# Altium schematic sheet Power_ext.SchDoc(Power_ext)
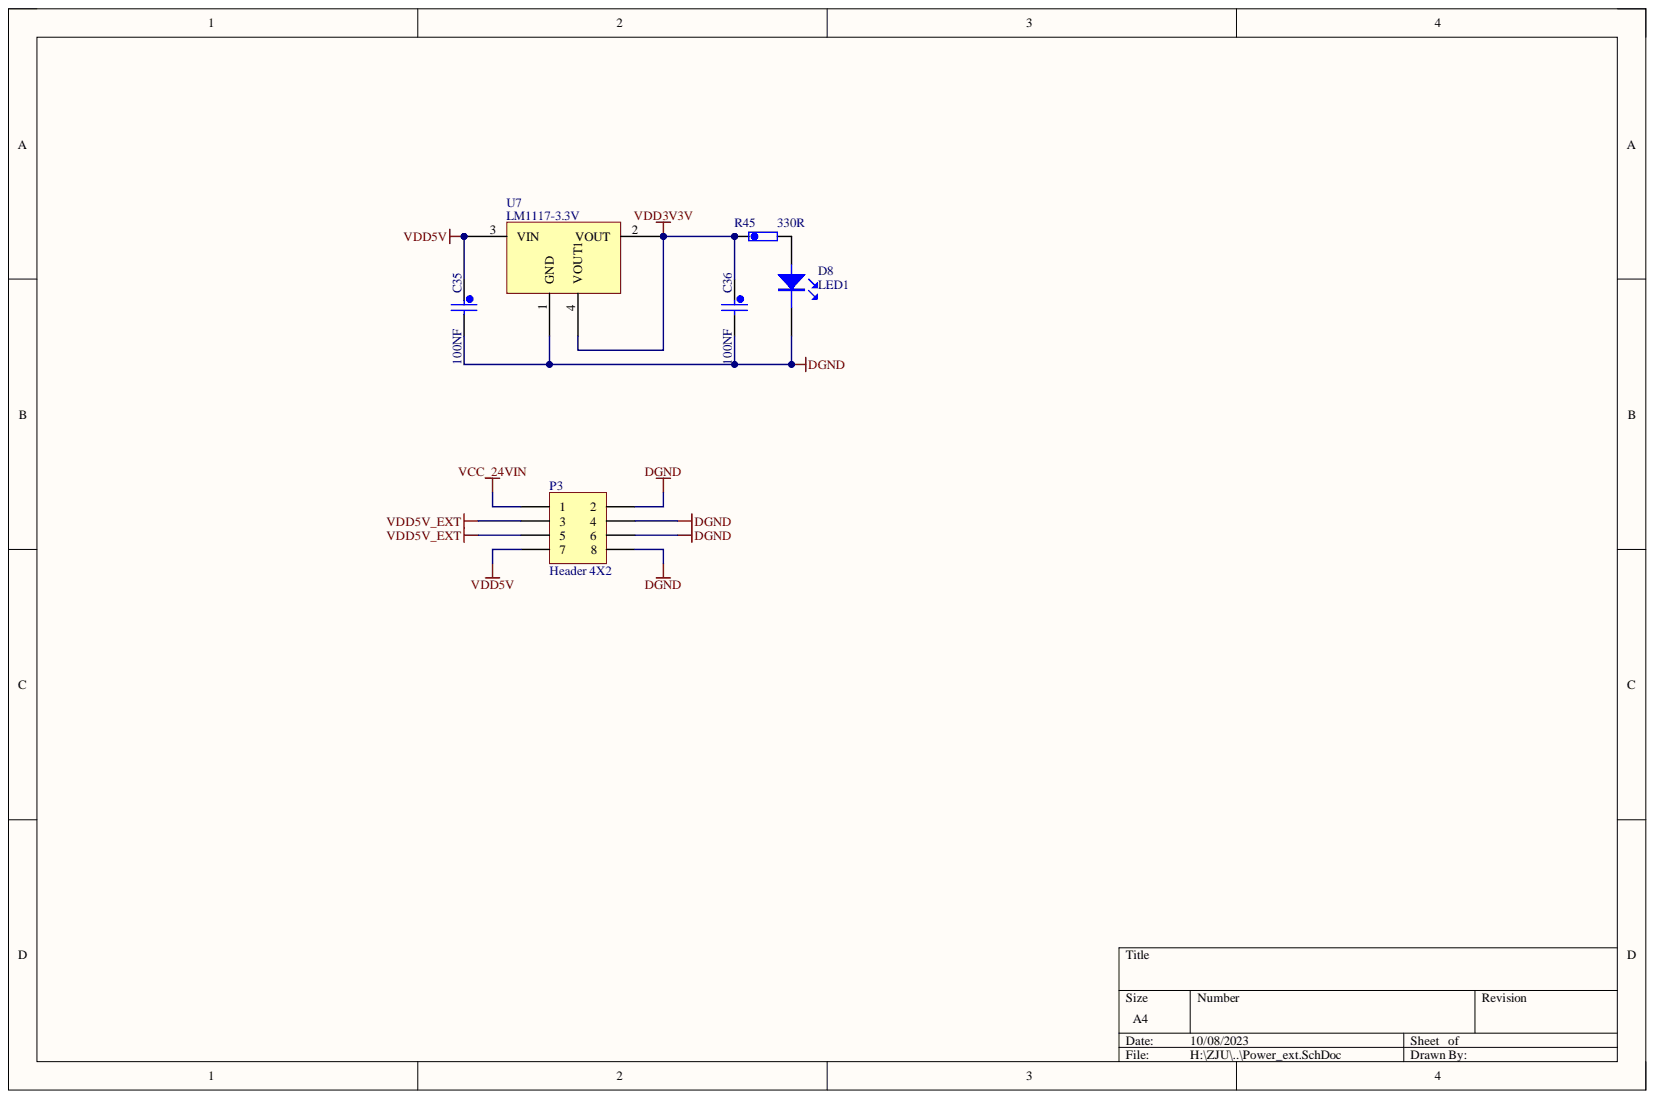
[diagram p0: Power_ext.SchDoc]

## Parts
C35  100NF  [0402C]
C36  100NF  [0402C]
D8  LED1  [LED-0603]
P3  Header 4X2  [HDR2X4]
R45  330R  [0402]
U7  LM1117-3.3V  [AMS1117]

## Nets
DGND: C35.2 C36.2 D8.2 P3.2 P3.4 P3.6 P3.8 U7.1(GND)
VCC_24VIN: P3.1
VDD3V3V: C36.1 R45.1 U7.2(VOUT) U7.4(VOUT1)
VDD5V: C35.1 P3.7 U7.3(VIN)
VDD5V_EXT: P3.3 P3.5
NetD8_1: D8.1 R45.2

## Library data of the parts
C35 C36 = CAP: Capacitors; Component Kind: Standard
D8 = LED: Light-Emitting Diode; Component Kind: Standard
P3 = Header 4X2: Header, 4-Pin, Dual row; Component Kind: Standard; LatestRevisionDate: 17-Jul-2002; LatestRevisionNote: Re-released for DXP Platform.; Library Name: Miscellaneous Connectors.IntLib; Signal Integrity: Connector; Publisher: Altium Limited
R45 = RESA: Resistance; Component Kind: Standard
U7 = AME1117: Component Kind: Standard
